# Altium schematic sheet mpc57_clk.SchDoc(mpc57_clk)
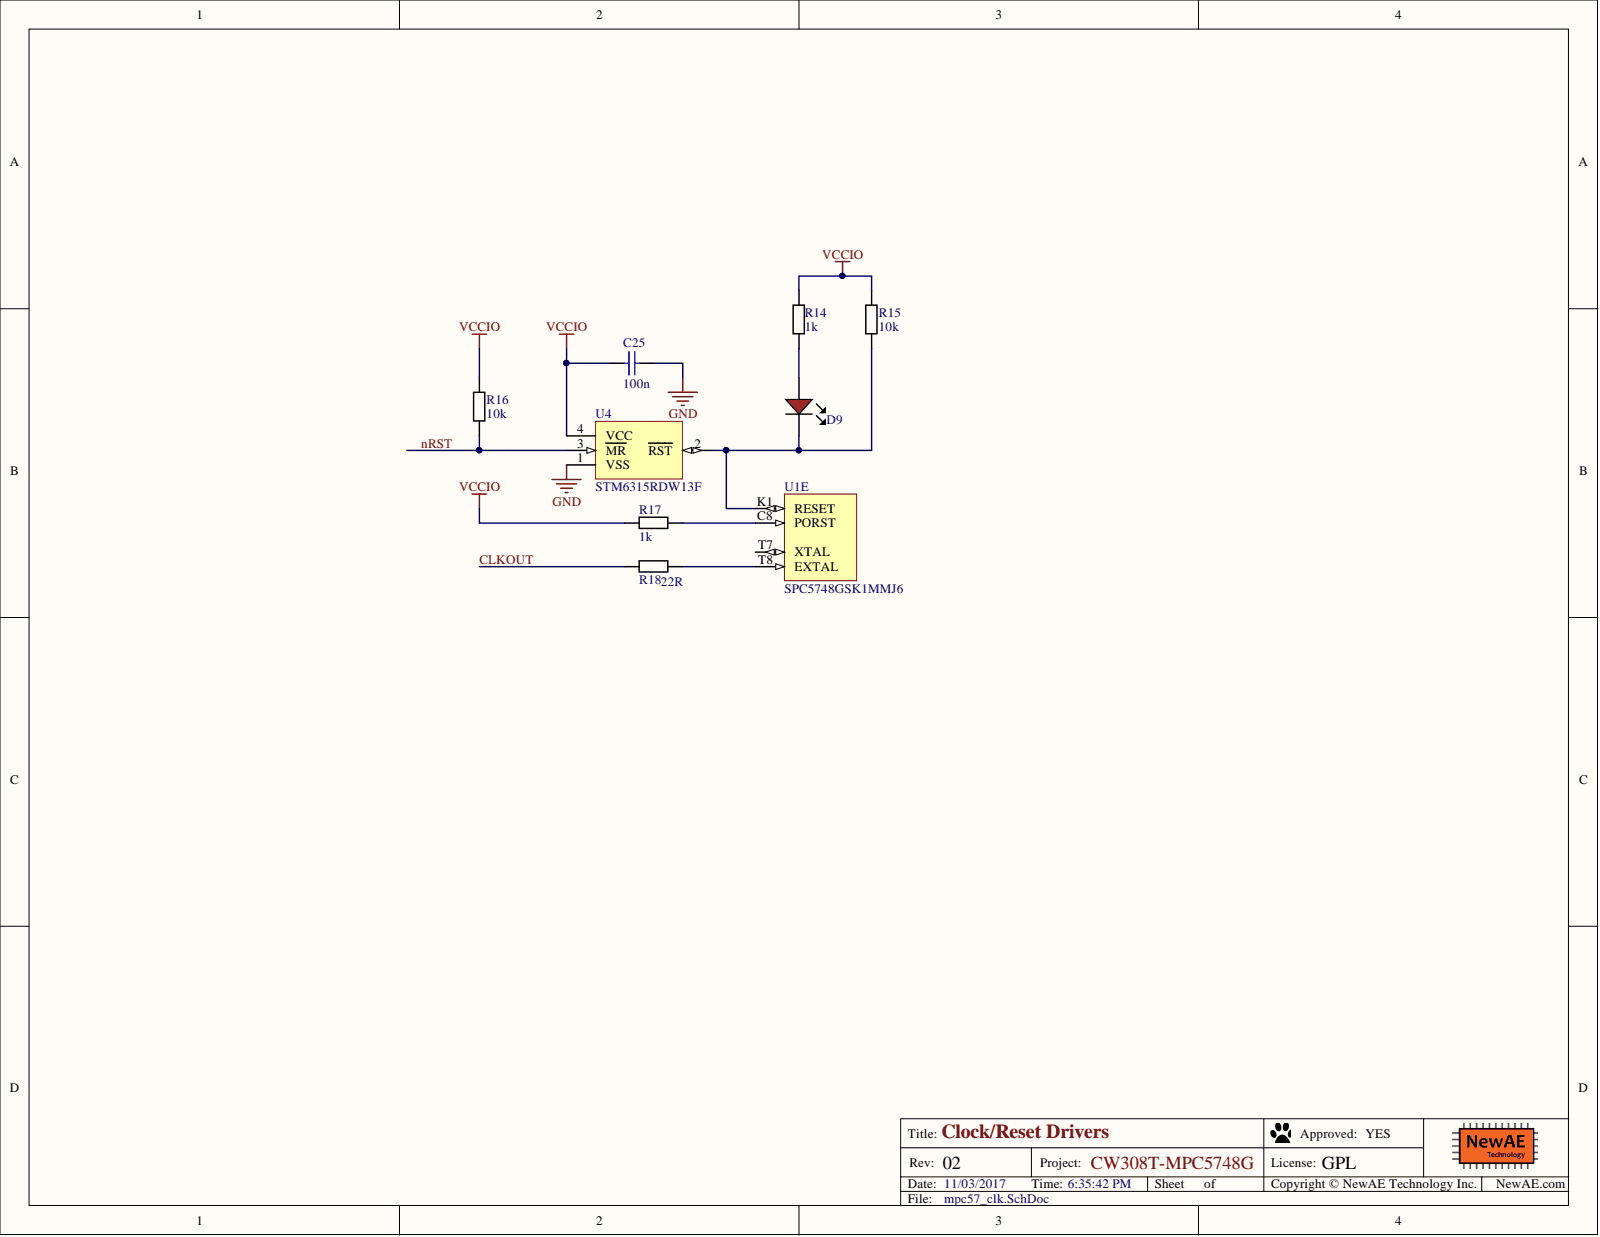
[diagram p0: mpc57_clk.SchDoc]

## Parts
C25  100n  [0402]
D9  LED, 0603, Red  [LED-0603-RED]  (value not drawn)
R14  1k  [0603]
R15  10k  [0603]
R16  10k  [0603]
R17  1k  [0603]
R18  22R  [0603]  (value not drawn)
U1  SPC5748GSK1MMJ6  [BGA256C100P16X16_1700X1700X170]  (part E)
U4  STM6315RDW13F  [SOT-143-4]

## Nets
GND: C25.2 U4.1(VSS)
VCCIO: C25.1 R14.1 R15.1 R16.1 R17.1 U4.4(VCC)
CLKOUT: R18.1
nRST: R16.2 U4.3(MR)
NetD9_A: D9.A R14.2
NetD9_K: D9.K R15.2 U1.K1(RESET) U4.2(RST)
NetR17_2: R17.2 U1.C8(PORST)
NetR18_2: R18.2 U1.T8(EXTAL)

Not connected: U1 T7(XTAL)

## Library data of the parts
C25 = CCHIP-100n-0402: 100n, 0402; Database Table Name: main; Value: 100n; Pin Count: 2; Part Number: CCHIP-100n-0402; Mounting Technology: SMT; Library Name: NewAE_AltiumLib.DBLib; Component Type: Standard; Component Kind: Standard; Case-EIA: 0402
D9 = LEDCHIP_0603_RED: Chip LED, Red; Database Table Name: main; Pin Count: 2; Part Number: LEDCHIP_0603_RED; Mounting Technology: SMT; Library Name: NewAE_AltiumLib.DBLib; Component Type: Standard; Component Kind: Standard
R14 R17 = RCHIP-1K-0603: 1k res, 0603, 1%, 1/10W; Manufacture Part Number 1: RC0603FR-071KL; Manufacture 1: Yageo; Rated Voltage: 75VDC; Rated Power: 0.1W; Database Table Name: main; Case-Metric: 1608; Value: 1k; Tolerance: 1%; Temperature Range: -55C to 155C; Supplier Part Number 1: 311-1.00KHRCT-ND; Supplier 1: Digi-Key; Pin Count: 2; Part Number: RCHIP-1K-0603; Mounting Technology: SMT; Library Name: NewAE_AltiumLib.DBLib; Component Type: Standard; Component Kind: Standard; Case-EIA: 0603
R15 R16 = RCHIP-10K-0603: 10k res, 0603, 1%, 1/10W; Manufacture Part Number 1: RC0603FR-0710KL; Manufacture 1: Yageo; Rated Voltage: 75VDC; Rated Power: 0.1W; Database Table Name: main; Case-Metric: 1608; Value: 10k; Tolerance: 1%; Temperature Range: -55C to 155C; Supplier Part Number 1: 311-10.0KHRCT-ND; Supplier 1: Digi-Key; Pin Count: 2; Part Number: RCHIP-10K-0603; Mounting Technology: SMT; Library Name: NewAE_AltiumLib.DBLib; Component Type: Standard; Component Kind: Standard; Case-EIA: 0603
R18 = RCHIP-22R-0603: 22R res, 0603, 1%, 1/10W; Manufacture Part Number 1: RC0603FR-0722RL; Manufacture 1: Yageo; Rated Voltage: 75VDC; Rated Power: 0.1W; Database Table Name: main; Case-Metric: 1608; Value: 22R; Tolerance: 1%; Temperature Range: -55C to 155C; Supplier Part Number 1: 311-22.0HRCT-ND; Supplier 1: Digi-Key; Pin Count: 2; Part Number: RCHIP-22R-0603; Mounting Technology: SMT; Library Name: NewAE_AltiumLib.DBLib; Component Type: Standard; Component Kind: Standard; Case-EIA: 0603
U1 = SPC5748GSK1MMJ6: Freescale 32-bit MCU, Triple core, 6MB Flash, 160MHz, -40/+125degC, Automotive Qualified, BGA 256; Library Name: NewAE_AltiumLib.SchLib; Component Kind: Standard
U4 = STM6315RDW13F: 2.93V Reset Controller, 112mS; Manufacture 1: ST Microelectronics; Pitch: 1.92mm; Database Table Name: main; Temperature Range: -40C to 125C; Supplier Part Number 1: 497-5127-1-ND; Supplier 1: Digi-Key; RoHS: Yes; Pin Count: 4; Part Number: STM6315RDW13F; Mounting Technology: SMT; Manufacture Part Number 1: STM6315RDW13F; Library Name: NewAE_AltiumLib.DBLib; Component Type: Standard; Component Kind: Standard
